# Altium schematic sheet InputSheet.SchDoc(Input)
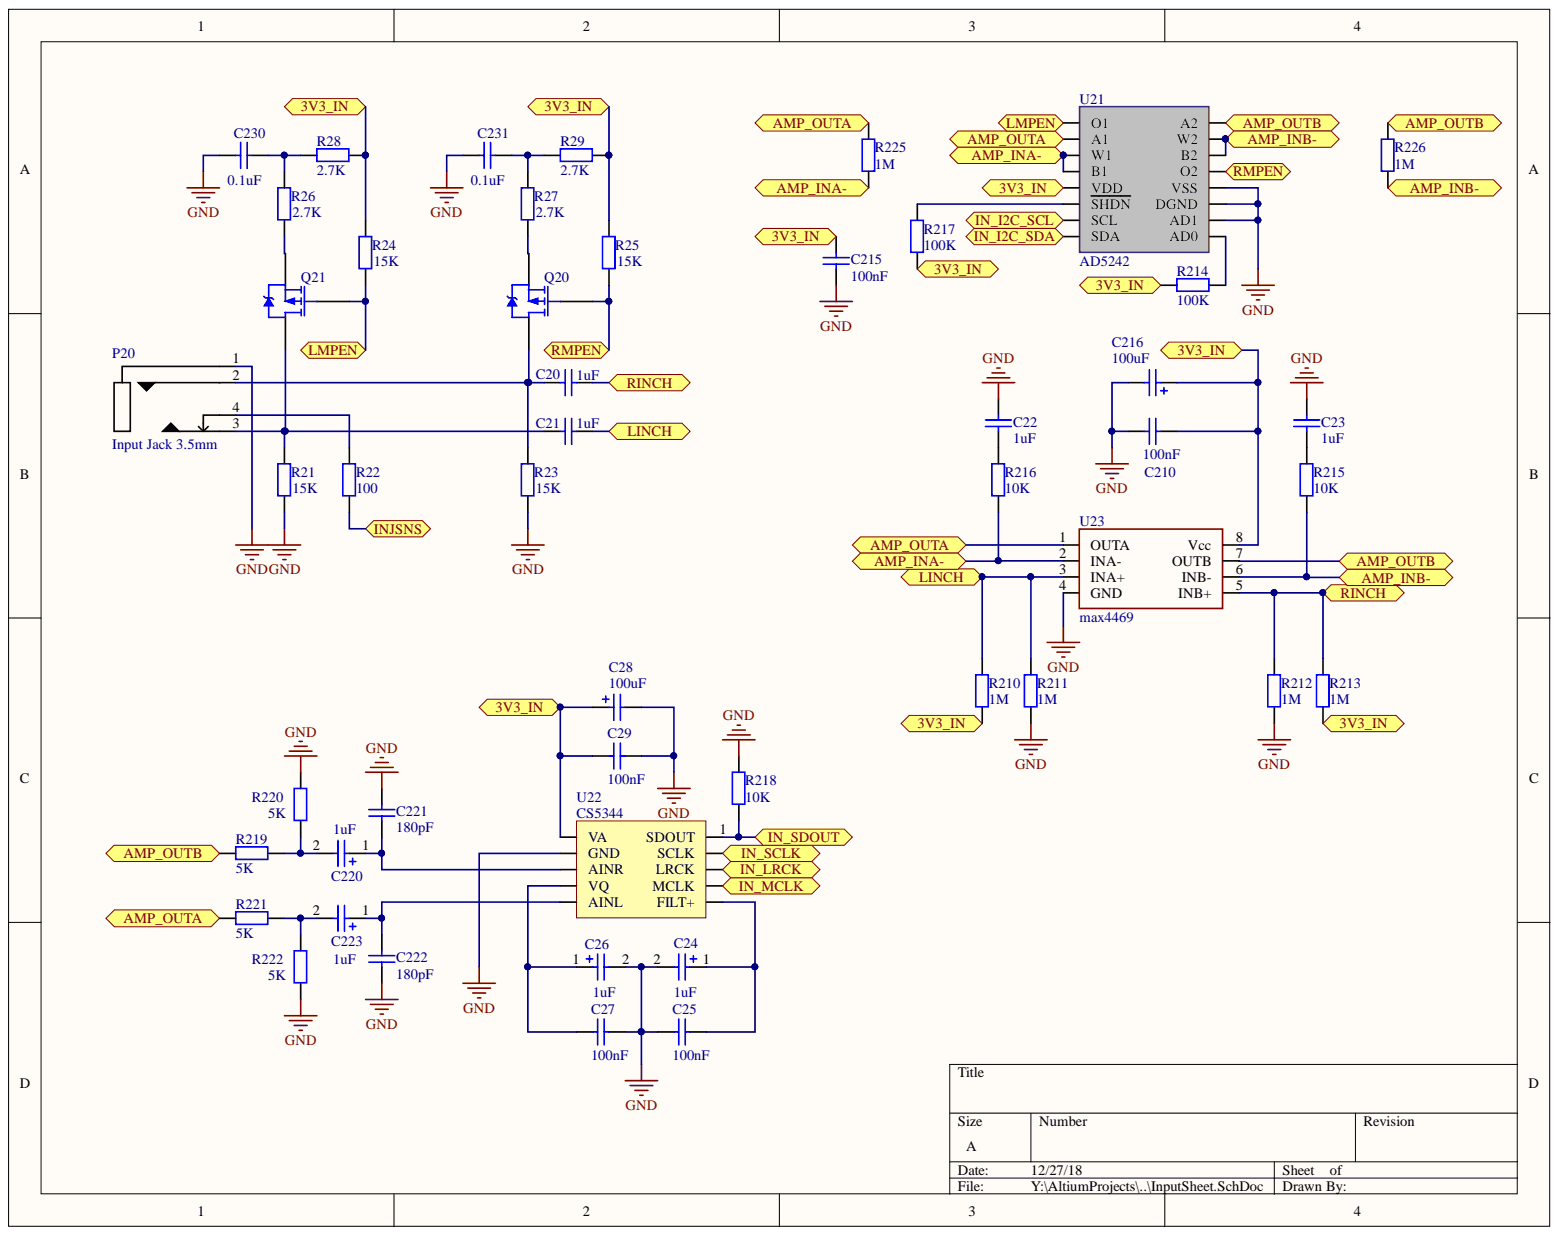
[diagram p0: InputSheet.SchDoc]

## Parts
C20  value 1uF  [0402]  (hidden comment: Cap)
C21  value 1uF  [0402]  (hidden comment: Cap)
C22  value 1uF  [0402]  (hidden comment: Cap)
C23  value 1uF  [0402]  (hidden comment: Cap)
C24  capacitance: 1uF  [TANT_A]  (hidden comment: TantCap)
C25  value 100nF  [0402]  (hidden comment: Cap)
C26  capacitance: 1uF  [TANT_A]  (hidden comment: TantCap)
C27  value 100nF  [0402]  (hidden comment: Cap)
C28  capacitance: 100uF  [TANT_B]  (hidden comment: TantCap)
C29  value 100nF  [0402]  (hidden comment: Cap)
C210  value 100nF  [0402]  (hidden comment: Cap)
C215  value 100nF  [0402]  (hidden comment: Cap)
C216  capacitance: 100uF  [TANT_B]  (hidden comment: TantCap)
C220  capacitance: 1uF  [TANT_A]  (hidden comment: TantCap)
C221  value 180pF  [0402]  (hidden comment: Cap)
C222  value 180pF  [0402]  (hidden comment: Cap)
C223  capacitance: 1uF  [TANT_A]  (hidden comment: TantCap)
C230  value 0.1uF  [0402]  (hidden comment: Cap)
C231  value 0.1uF  [0402]  (hidden comment: Cap)
P20  Input Jack 3.5mm  [PJ327C]
Q20  IRLML6401  [SOT-23]  (value not drawn)
Q21  IRLML6401  [SOT-23]  (value not drawn)
R21  value 15K  [0402]  (hidden comment: Res2)
R22  value 100  [0402]  (hidden comment: Res2)
R23  value 15K  [0402]  (hidden comment: Res2)
R24  value 15K  [0402]  (hidden comment: Res2)
R25  value 15K  [0402]  (hidden comment: Res2)
R26  value 2.7K  [0402]  (hidden comment: Res2)
R27  value 2.7K  [0402]  (hidden comment: Res2)
R28  value 2.7K  [0402]  (hidden comment: Res2)
R29  value 2.7K  [0402]  (hidden comment: Res2)
R210  value 1M  [0402]  (hidden comment: Res2)
R211  value 1M  [0402]  (hidden comment: Res2)
R212  value 1M  [0402]  (hidden comment: Res2)
R213  value 1M  [0402]  (hidden comment: Res2)
R214  value 100K  [0402]  (hidden comment: Res2)
R215  value 10K  [0402]  (hidden comment: Res2)
R216  value 10K  [0402]  (hidden comment: Res2)
R217  value 100K  [0402]  (hidden comment: Res2)
R218  value 10K  [0402]  (hidden comment: Res2)
R219  value 5K  [0402]  (hidden comment: Res2)
R220  value 5K  [0402]  (hidden comment: Res2)
R221  value 5K  [0402]  (hidden comment: Res2)
R222  value 5K  [0402]  (hidden comment: Res2)
R225  value 1M  [0402]  (hidden comment: Res2)
R226  value 1M  [0402]  (hidden comment: Res2)
U21  AD5242  [TSSOP16]
U22  CS5344  [10LD TSSOP]
U23  max4469  [SOT23-8]

## Ports
3V3_IN, AMP_INA-, AMP_INB-, AMP_OUTA, AMP_OUTB, IN_I2C_SCL, IN_I2C_SDA, IN_LRCK, IN_MCLK, IN_SCLK, IN_SDOUT, INJSNS, LINCH, LMPEN, RINCH, RMPEN

## Nets
GND: C22.2 C23.2 C24.2 C25.2 C26.2 C27.1 C28.2 C29.1 C210.2 C215.1 C216.2 C221.1 C222.2 C230.1 C231.1 P20.1 R21.1 R23.1 R211.2 R212.2 R218.1 R220.2 R222.1 U21.10(AD1) U21.11(DGND) U21.12(VSS) U22.9(GND) U23.4(GND)
3V3_IN: C28.1 C29.2 C210.1 C215.2 C216.1 R24.2 R25.2 R28.1 R29.2 R210.2 R213.2 R214.1 R217.1 U21.5(VDD) U22.10(VA) U23.8(Vcc) ; port 3V3_IN
AMP_INA-: R216.2 R225.2 U21.3(W1) U21.4(B1) U23.2(INA-) ; port AMP_INA-
AMP_INB-: R215.2 R226.2 U21.14(B2) U21.15(W2) U23.6(INB-) ; port AMP_INB-
AMP_OUTA: R221.1 R225.1 U21.2(A1) U23.1(OUTA) ; port AMP_OUTA
AMP_OUTB: R219.1 R226.1 U21.16(A2) U23.7(OUTB) ; port AMP_OUTB
IN_I2C_SCL: U21.7(SCL) ; port IN_I2C_SCL
IN_I2C_SDA: U21.8(SDA) ; port IN_I2C_SDA
IN_LRCK: U22.3(LRCK) ; port IN_LRCK
IN_MCLK: U22.4(MCLK) ; port IN_MCLK
IN_SCLK: U22.2(SCLK) ; port IN_SCLK
IN_SDOUT: R218.2 U22.1(SDOUT) ; port IN_SDOUT
INJSNS: R22.2 ; port INJSNS
LINCH: C21.2 R210.1 R211.1 U23.3(INA+) ; port LINCH
LMPEN: Q21.1 R24.1 U21.1(O1) ; port LMPEN
RINCH: C20.2 R212.1 R213.1 U23.5(INB+) ; port RINCH
RMPEN: Q20.1 R25.1 U21.13(O2) ; port RMPEN
NetC20_1: C20.1 P20.2 Q20.3 R23.2
NetC21_1: C21.1 P20.3 Q21.3 R21.2
NetC22_1: C22.1 R216.1
NetC23_1: C23.1 R215.1
NetC24_1: C24.1 C25.1 U22.5(FILT+)
NetC26_1: C26.1 C27.2 U22.7(VQ)
NetC220_1: C220.1 C221.2 U22.8(AINR)
NetC220_2: C220.2 R219.2 R220.1
NetC222_1: C222.1 C223.1 U22.6(AINL)
NetC223_2: C223.2 R221.2 R222.2
NetC230_2: C230.2 R26.2 R28.2
NetC231_2: C231.2 R27.2 R29.1
NetP20_4: P20.4 R22.1
NetQ20_2: Q20.2 R27.1
NetQ21_2: Q21.2 R26.1
NetR214_2: R214.2 U21.9(AD0)
NetR217_2: R217.2 U21.6(SHDN)

## Library data of the parts
C20-C23 C25 C27 C29 C210 C215 C221 C222 C230 C231 = Cap: Capacitor; Simulation: CAP; Signal Integrity: Cap; Published: 8-Jun-2000; PackageReference: RAD-0.3; PackageDescription: Radial Cap, Thru-Hole; 2 Leads; 0.3 in Pin Spacing; Library Name: Miscellaneous Devices.IntLib; LatestRevisionNote: Re-released for DXP Platform.; LatestRevisionDate: 17-Jul-2002; Component Kind: Standard; Publisher: Altium Limited
C24 C26 C28 C216 C220 C223 = TantalCap: Component Kind: Standard
  C24 C26 C220 C223: Library Name: Diploma.IntLib
  C28 C216: Library Name: Dimploma2Library.IntLib
P20 = PJ327C: Component Kind: Standard; Library Name: Dimploma2Library.IntLib
Q20 Q21 = IRLML6401: P-Channel MOSFET; Component Kind: Standard; Library Name: Diploma.IntLib
R21-R29 R210-R222 R225 R226 = Res2: Resistor; Simulation: RESISTOR; Signal Integrity: Res; Published: 8-Jun-2000; PackageReference: AXIAL-0.4; PackageDescription: Axial Device, Thru-Hole; 2 Leads; 0.4 in Pin Spacing; Library Name: Miscellaneous Devices.IntLib; LatestRevisionNote: Re-released for DXP Platform.; LatestRevisionDate: 17-Jul-2002; Component Kind: Standard; Publisher: Altium Limited
U21 = AD5242: Component Kind: Standard; Library Name: Dimploma2Library.IntLib
U22 = CS5344: Component Kind: Standard; Library Name: Cirrus Logic.IntLib
U23 = max4469: Component Kind: Standard; Library Name: Diploma.IntLib
